# Altium schematic sheet RIOT.SchDoc
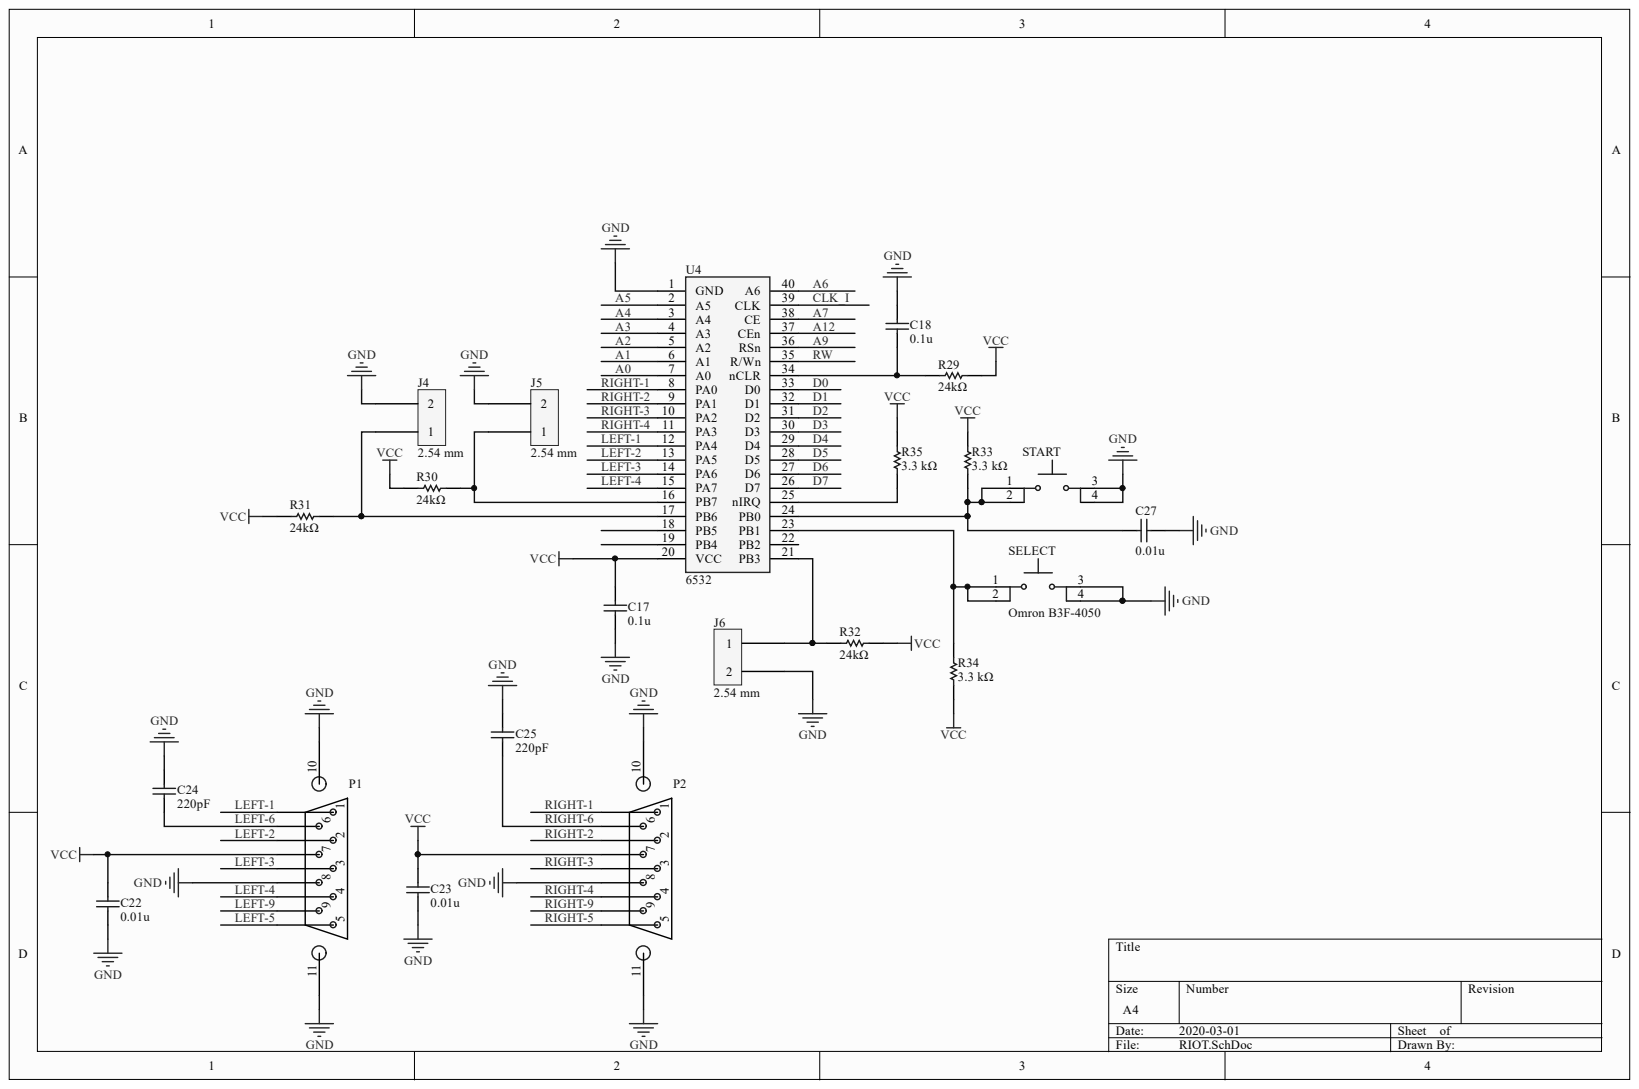
[diagram p0: RIOT.SchDoc]

## Parts
C17  Capacitance: 0.1u  [CAPC2013X140X45ML15T25]  (hidden comment: Murata GCM21BR71E104KA37L)
C18  Capacitance: 0.1u  [CAPC2013X140X45ML15T25]  (hidden comment: Murata GCM21BR71E104KA37L)
C22  Capacitance: 0.01u  [CAPC2013X140X45ML15T25]  (hidden comment: Murata GCM21BR71E104KA37L)
C23  Capacitance: 0.01u  [CAPC2013X140X45ML15T25]  (hidden comment: Murata GCM21BR71E104KA37L)
C24  Capacitance: 220pF  [CAPC2013X140X45ML15T25]  (hidden comment: Murata GCM21BR71E104KA37L)
C25  Capacitance: 220pF  [CAPC2013X140X45ML15T25]  (hidden comment: Murata GCM21BR71E104KA37L)
C27  Capacitance: 0.01u  [CAPC2013X140X45ML15T25]  (hidden comment: Murata GCM21BR71E104KA37L)
J4  Pin Pitch: 2.54 mm  [PCB-67oirgeg237u6k9yh29o-1]  (hidden comment: FCI 67997-102HLF)
J5  Pin Pitch: 2.54 mm  [PCB-67oirgeg237u6k9yh29o-1]  (hidden comment: FCI 67997-102HLF)
J6  Pin Pitch: 2.54 mm  [PCB-67oirgeg237u6k9yh29o-1]  (hidden comment: FCI 67997-102HLF)
P1  TE Connectivity 5747250-4  [PCB-r2jzczhidapsviihcs8o-1]  (value not drawn)
P2  TE Connectivity 5747250-4  [PCB-r2jzczhidapsviihcs8o-1]  (value not drawn)
R29  Resistance: 24kΩ  [PCB-jqva0n1m8ejtn3mkjxws-1]  (hidden comment: TE Connectivity LR1F10K)
R30  Resistance: 24kΩ  [PCB-jqva0n1m8ejtn3mkjxws-1]  (hidden comment: TE Connectivity LR1F10K)
R31  Resistance: 24kΩ  [PCB-jqva0n1m8ejtn3mkjxws-1]  (hidden comment: TE Connectivity LR1F10K)
R32  Resistance: 24kΩ  [PCB-jqva0n1m8ejtn3mkjxws-1]  (hidden comment: TE Connectivity LR1F10K)
R33  Resistance: 3.3 kΩ  [PCB-jqva0n1m8ejtn3mkjxws-1]  (hidden comment: TE Connectivity LR1F10K)
R34  Resistance: 3.3 kΩ  [PCB-jqva0n1m8ejtn3mkjxws-1]  (hidden comment: TE Connectivity LR1F10K)
R35  Resistance: 3.3 kΩ  [PCB-jqva0n1m8ejtn3mkjxws-1]  (hidden comment: TE Connectivity LR1F10K)
SELECT  Omron B3F-4050  [PCB-f7mxrp64k8suv8d5sj09-1]
START  Omron B3F-4050  [PCB-f7mxrp64k8suv8d5sj09-1]
U4  6532  [PCB-d7c37p5xoyzvnp9i1zhr-1]

## Nets
GND: C17.1 C18.2 C22.2 C23.2 C24.1 C25.1 C27.1 J4.2 J5.2 J6.2 P1.8 P1.10 P1.11 P2.8 P2.10 P2.11 SELECT.3 SELECT.4 START.3 START.4 U4.1(GND)
VCC: C17.2 C22.1 C23.1 P1.7 P2.7 R29.2 R30.1 R31.1 R32.2 R33.1 R34.2 R35.1 U4.20(VCC)
A0: U4.7(A0)
A1: U4.6(A1)
A2: U4.5(A2)
A3: U4.4(A3)
A4: U4.3(A4)
A5: U4.2(A5)
A6: U4.40(A6)
A7: U4.38(CE)
A9: U4.36(RSn)
A12: U4.37(CEn)
CLK_I: U4.39(CLK)
D0: U4.33(D0)
D1: U4.32(D1)
D2: U4.31(D2)
D3: U4.30(D3)
D4: U4.29(D4)
D5: U4.28(D5)
D6: U4.27(D6)
D7: U4.26(D7)
LEFT-1: P1.1 U4.12(PA4)
LEFT-2: P1.2 U4.13(PA5)
LEFT-3: P1.3 U4.14(PA6)
LEFT-4: P1.4 U4.15(PA7)
LEFT-5: P1.5
LEFT-6: C24.2 P1.6
LEFT-9: P1.9
RIGHT-1: P2.1 U4.8(PA0)
RIGHT-2: P2.2 U4.9(PA1)
RIGHT-3: P2.3 U4.10(PA2)
RIGHT-4: P2.4 U4.11(PA3)
RIGHT-5: P2.5
RIGHT-6: C25.2 P2.6
RIGHT-9: P2.9
RW: U4.35(R/Wn)
NetC18_1: C18.1 R29.1 U4.34(nCLR)
NetC27_2: C27.2 R33.2 START.1 START.2 U4.24(PB0)
NetJ4_1: J4.1 R31.2 U4.17(PB6)
NetJ5_1: J5.1 R30.2 U4.16(PB7)
NetJ6_1: J6.1 R32.1 U4.21(PB3)
NetR34_1: R34.1 SELECT.1 SELECT.2 U4.23(PB1)
NetR35_2: R35.2 U4.25(nIRQ)

Not connected: U4 18(PB5) 19(PB4) 22(PB2)

## Library data of the parts
C17 C18 C22 C23 C27 = CMP-135214-2: CAP CER 0.1UF 25V 10% X7R 0805; Voltage Rating (DC): 25.0 V; Capacitance Tolerance: ±10%; Case/Package: 0805; Component Kind: Standard; Dielectric Characteristic: X7R; Library Name: CommunityVault; OctopartID: e78b2f8c9518384c; Octopart Url: https://octopart.com/gcm21br71e104ka37l-murata-17651701; RoHS: Compliant
C24 C25 = CMP-135214-2: CAP CER 220pF 25V 10% X7R 0805; Voltage Rating (DC): 25.0 V; Capacitance Tolerance: ±10%; Case/Package: 0805; Component Kind: Standard; Dielectric Characteristic: X7R; Library Name: CommunityVault; OctopartID: e78b2f8c9518384c; Octopart Url: https://octopart.com/gcm21br71e104ka37l-murata-17651701; RoHS: Compliant
J4-J6 = CMP-7b1eb2790601a4d9-1: Conn Unshrouded Header HDR 2 POS Solder ST Thru-Hole Poly Bag; Component Kind: Standard; Contact Material: Phosphor Bronze; Contact Plating: Gold; Library Name: CommunityVault; Number of Rows: 2; OctopartID: 7b1eb2790601a4d9; Octopart URL: http://octopart.com/67997-102hlf-fci-7166073
P1 P2 = CMP-5d7596bab4e282b2-5: Connector D-Subminiature Plug 9 Position 2.74mm Solder Right Angle Through Hole 9 Terminal 1 Port; ELV Compliant: Compliant; Gender: Male; Lead-Free Status: Lead Free; Number of Contacts: 9; Color: Black; Component Kind: Standard; Contact Material: Brass; Contact Plating: Gold, Tin over Nickel; Flammability Rating: UL 94 V-0; Housing Color: Black; Housing Material: Nylon; Library Name: CommunityVault; Lifecycle Status: Active; Manufacturer Part Number: 5747250-4; Mounting Style: Through Hole; Number of Positions: 9; Number of Rows: 2; OctopartID: 5d7596bab4e282b2; Octopart URL: https://octopart.com/5747250-4-te+connectivity-46517489; Orientation: Right Angle; Packaging: Tray; Pin Pitch: 2.74 mm; REACH SVHC Compliance: No SVHC; RoHS: Compliant; Size-Height: 12.6 mm; Size-Length: 30.8 mm; Size-Width: 18.6 mm
R29-R32 = CMP-0a5cc3a37cecd59b-6: Res Metal Film 24K Ohm 1% 3/5W; ELV Compliant: Compliant; Component Kind: Standard; Library Name: CommunityVault; Manufacturer Part Number: LR1F10K; Packaging: Ammo Pack; REACH SVHC Compliance: No SVHC; RoHS: Compliant
R33-R35 = CMP-0a5cc3a37cecd59b-6: Res Metal Film 3.3K Ohm 1% 3/5W ±50ppm/°C Conformal AXL Thru-Hole Ammo Pack; ELV Compliant: Compliant; Component Kind: Standard; Library Name: CommunityVault; Manufacturer Part Number: LR1F10K; Packaging: Ammo Pack; REACH SVHC Compliance: No SVHC; RoHS: Compliant
SELECT START = CMP-0554cc5e77dcda93-1: Switch, Flux tight Mechanical Keyswitch, , 50MA@24VDC, No Ground Term., Flat Plung.; Actuator Type: Standard; Contact Current Rating: 50.0 mA; Contact Resistance: 100 mΩ; Component Kind: Standard; Contact Material: Phosphor Bronze; Contact Plating: Silver; Library Name: CommunityVault; REACH SVHC Compliance: No SVHC; Size-Width: 12.0 mm
U4 = CMP-ie4rvhavsnxg4jtupdlp-1: 6532 RIOT; Component Kind: Standard; CustomComponentID: ie4rvhavsnxg4jtupdlp; Library Name: FavoritesLibrary
